# Altium schematic sheet FPGA.SchDoc
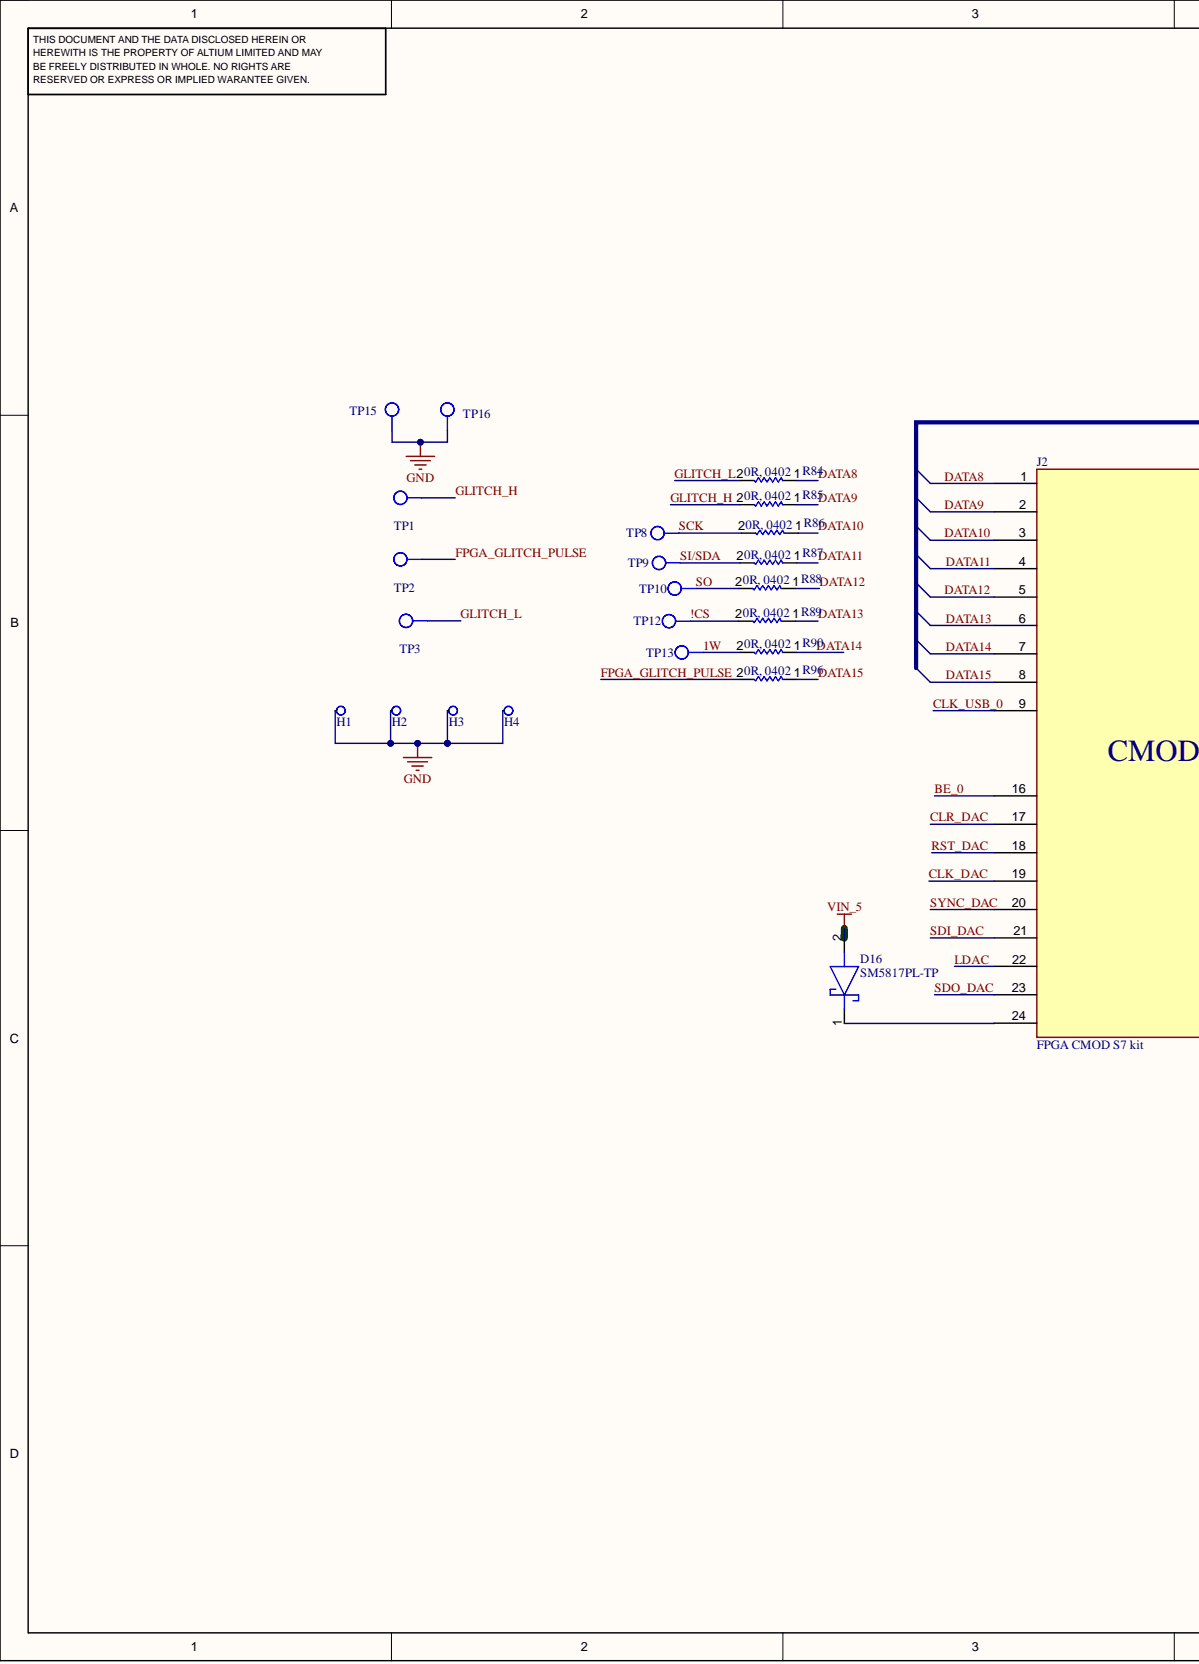
[diagram p0: FPGA.SchDoc, left]
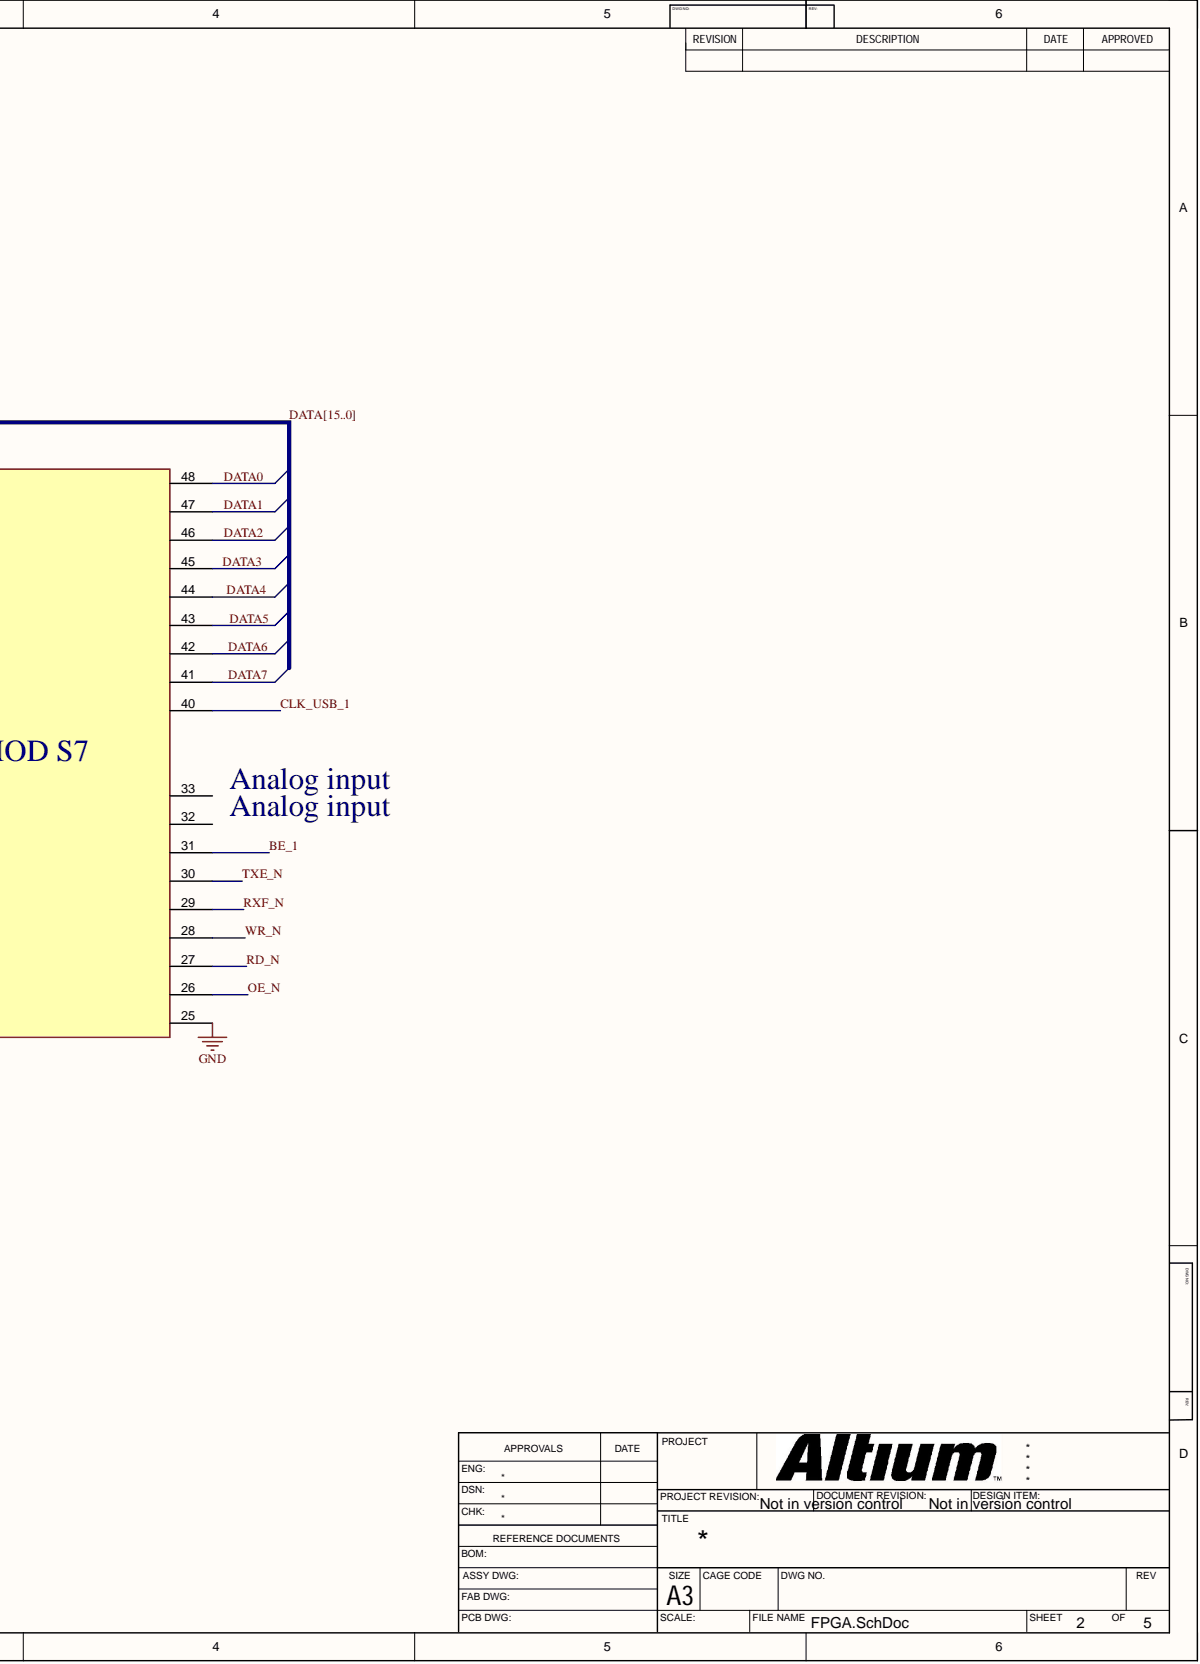
[diagram p1: FPGA.SchDoc, right]

## Parts
D16  SM5817PL-TP  [SOD3716X120N]
H1  PCB_spacer  [PCB_spacer]  (value not drawn)
H2  PCB_spacer  [PCB_spacer]  (value not drawn)
H3  PCB_spacer  [PCB_spacer]  (value not drawn)
H4  PCB_spacer  [PCB_spacer]  (value not drawn)
J2  FPGA CMOD S7 kit  [CMOD S7]
R84  0R, 0402  [RES0402]
R85  0R, 0402  [RES0402]
R86  0R, 0402  [RES0402]
R87  0R, 0402  [RES0402]
R88  0R, 0402  [RES0402]
R89  0R, 0402  [RES0402]
R90  0R, 0402  [RES0402]
R96  0R, 0402  [RES0402]
TP1  Test Point  [TP_1mm]  (value not drawn)
TP2  Test Point  [TP_1mm]  (value not drawn)
TP3  Test Point  [TP_1mm]  (value not drawn)
TP8  Test Point  [TP_pad]  (value not drawn)
TP9  Test Point  [TP_pad]  (value not drawn)
TP10  Test Point  [TP_pad]  (value not drawn)
TP12  Test Point  [TP_pad]  (value not drawn)
TP13  Test Point  [TP_pad]  (value not drawn)
TP15  Test Point  [TP_1mm]  (value not drawn)
TP16  Test Point  [TP_1mm]  (value not drawn)

## Nets
GND: H1.1 H2.1 H3.1 H4.1 J2.25 TP15.1 TP16.1
VIN_5: D16.2
1W: R90.2 TP13.1
!CS: R89.2 TP12.1
BE_0: J2.16
BE_1: J2.31
CLK_DAC: J2.19
CLK_USB_0: J2.9
CLK_USB_1: J2.40
CLR_DAC: J2.17
DATA0: J2.48  [also labelled DATA]
DATA1: J2.47  [also labelled DATA]
DATA2: J2.46  [also labelled DATA]
DATA3: J2.45  [also labelled DATA]
DATA4: J2.44  [also labelled DATA]
DATA5: J2.43  [also labelled DATA]
DATA6: J2.42  [also labelled DATA]
DATA7: J2.41  [also labelled DATA]
DATA8: J2.1 R84.1  [also labelled DATA]
DATA9: J2.2 R85.1  [also labelled DATA]
DATA10: J2.3 R86.1  [also labelled DATA]
DATA11: J2.4 R87.1  [also labelled DATA]
DATA12: J2.5 R88.1  [also labelled DATA]
DATA13: J2.6 R89.1  [also labelled DATA]
DATA14: J2.7 R90.1  [also labelled DATA]
DATA15: J2.8 R96.1  [also labelled DATA]
FPGA_GLITCH_PULSE: R96.2 TP2.1
GLITCH_H: R85.2 TP1.1
GLITCH_L: R84.2 TP3.1
LDAC: J2.22
OE_N: J2.26
RD_N: J2.27
RST_DAC: J2.18
RXF_N: J2.29
SCK: R86.2 TP8.1
SDI_DAC: J2.21
SDO_DAC: J2.23
SI/SDA: R87.2 TP9.1
SO: R88.2 TP10.1
SYNC_DAC: J2.20
TXE_N: J2.30
WR_N: J2.28
NetD16_1: D16.1 J2.24

Not connected: J2 32 33

## Library data of the parts
D16 = SM5817PL-TP: Schottky Diode; Manufacturer_Name: MCC; Arrow Part Number: SM5817PL-TP; Arrow Price/Stock: https://www.arrow.com/en/products/sm5817pl-tp/micro-commercial-components?utm_currency=USD&region=nac; Component Kind: Standard; Datasheet Link: https://mccsemi.com/pdf/Products/SM5817PL-SM5819PL(SOD-123FL).pdf; Height: 1.2mm; Manufacturer_Part_Number: SM5817PL-TP; Mouser Part Number: 833-SM5817PL-TP; Mouser Price/Stock: https://www.mouser.co.uk/ProductDetail/Micro-Commercial-Components-MCC/SM5817PL-TP?qs=SdqRYZZ9IxAlhPsFLNd53A%3D%3D; Library Name: Sanket.SchLib
J2 = CMOD S7: CMOD S7 - Xilinx Spartan-7 FPGA (XC7S25-1CSGA225C); Component Kind: Standard; Library Name: Sanket.SchLib
R84-R90 R96 = Resistor: RES 0 OHM JUMPER 1/16W 0402; Manufacturer_Name: YAGEO; Manufacturer_Part_Number: RC0402JR-070RL; Component Kind: Standard; Library Name: Sanket.SchLib
H1-H4 = PCB_spacer: Component Kind: Standard; Library Name: Sanket.SchLib
TP1-TP3 TP8-TP10 TP12 TP13 TP15 TP16 = Test Point: Component Kind: Standard; Library Name: Sanket.SchLib
